# Altium schematic sheet SX1280_Power_Display_e394v02a.SchDoc(SX1280_Power_Display_e394v02a)
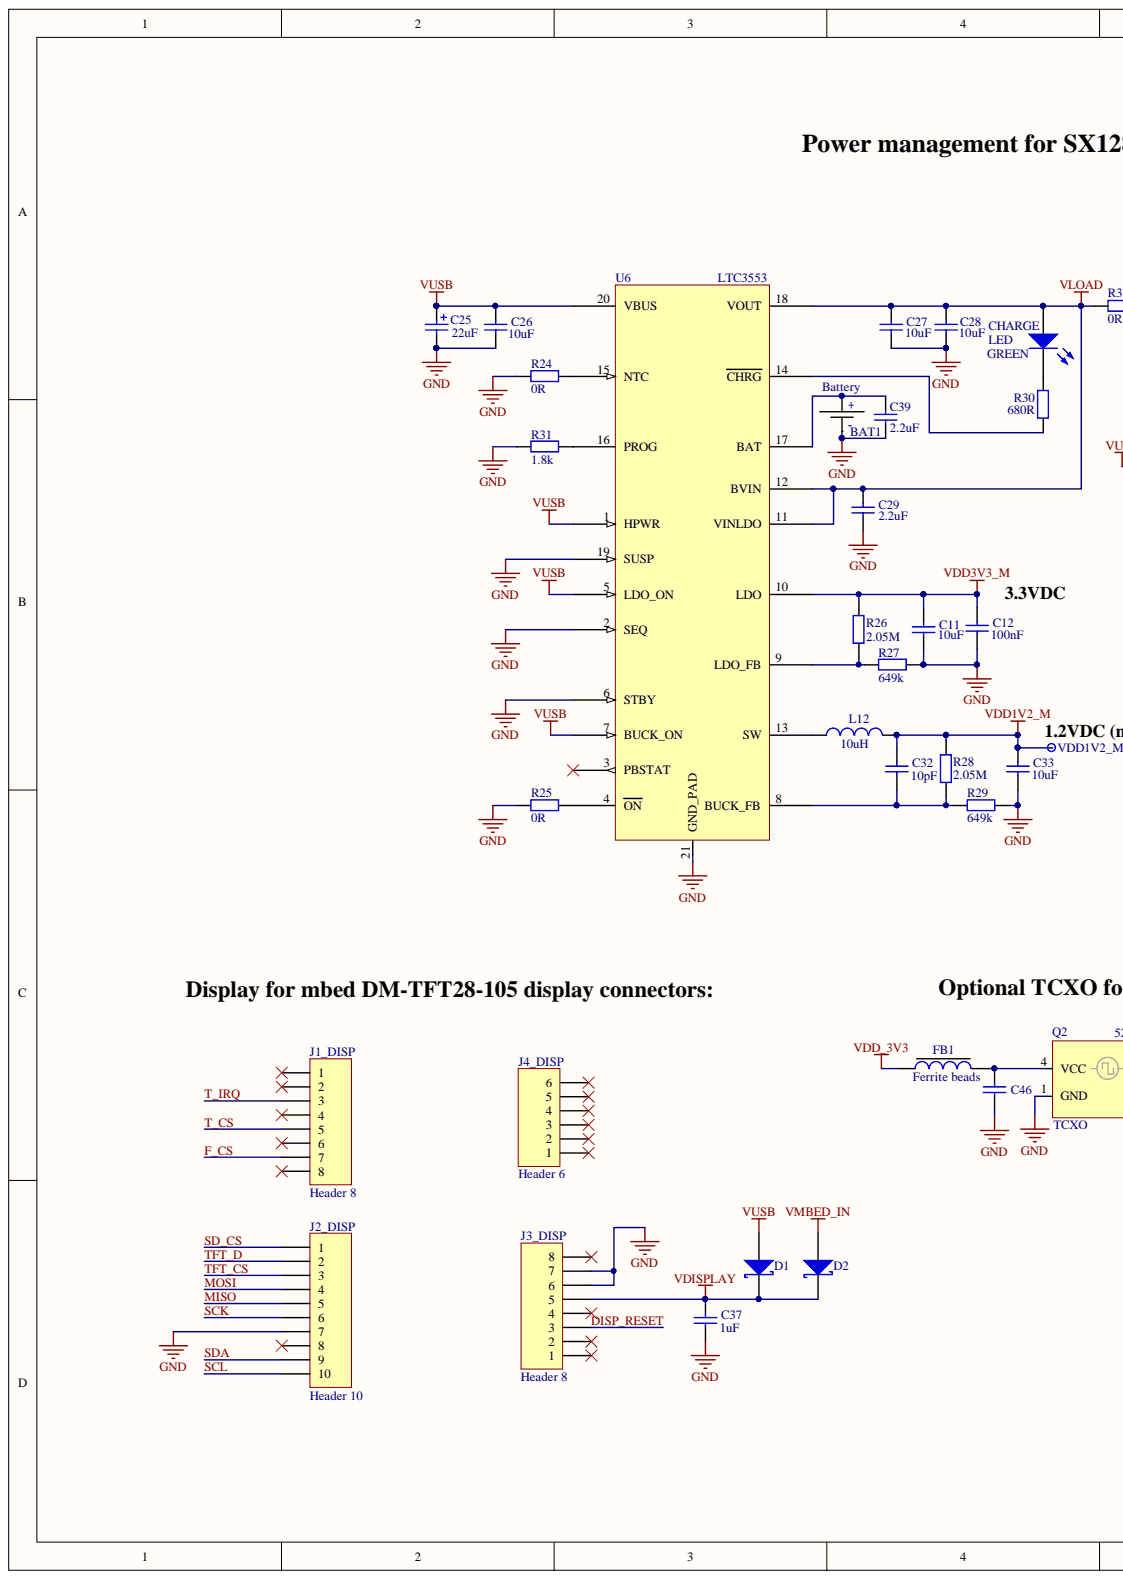
[diagram p0: SX1280_Power_Display_e394v02a.SchDoc, left]
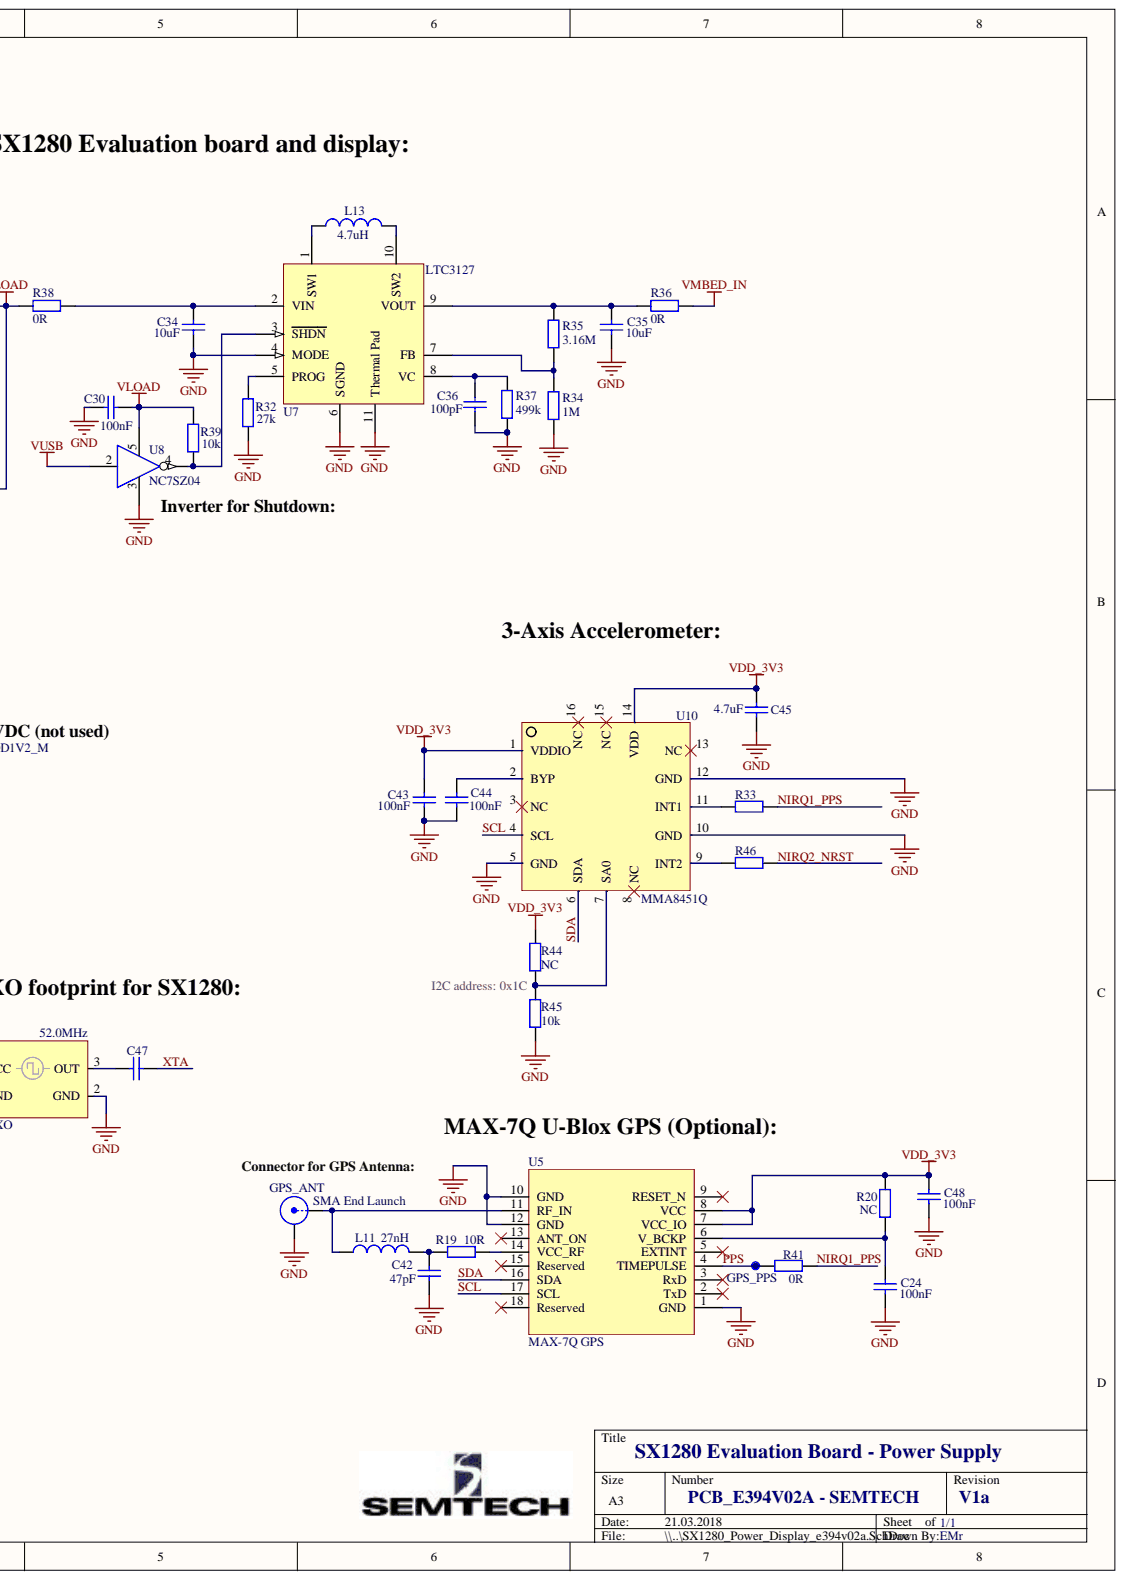
[diagram p1: SX1280_Power_Display_e394v02a.SchDoc, right]

## Parts
BAT1  Battery  [S2B-PH-K-S]
C11  value 10uF  [rlc_805_smd]  (hidden comment: Cap)
C12  value 100nF  [rlc_402_smd]  (hidden comment: Cap)
C24  value 100nF  [rlc_402_smd]  (hidden comment: Cap)
C25  value 22uF  [CD3216-1206]  (hidden comment: Cap Pol)
C26  value 10uF  [rlc_805_smd]  (hidden comment: Cap)
C27  value 10uF  [rlc_805_smd]  (hidden comment: Cap)
C28  value 10uF  [rlc_805_smd]  (hidden comment: Cap)
C29  value 2.2uF  [rlc_603_smd]  (hidden comment: Cap)
C30  value 100nF  [rlc_402_smd]  (hidden comment: Cap)
C32  value 10pF  [rlc_402_smd]  (hidden comment: Cap)
C33  value 10uF  [rlc_805_smd]  (hidden comment: Cap)
C34  value 10uF  [rlc_805_smd]  (hidden comment: Cap)
C35  value 10uF  [rlc_805_smd]  (hidden comment: Cap)
C36  value 100pF  [rlc_402_smd]  (hidden comment: Cap)
C37  value 1uF  [rlc_402_smd]  (hidden comment: Cap)
C39  value 2.2uF  [rlc_603_smd]  (hidden comment: Cap)
C42  value 47pF  [rlc_402_smd]  (hidden comment: Cap)
C43  value 100nF  [rlc_402_smd]  (hidden comment: Cap)
C44  value 100nF  [rlc_402_smd]  (hidden comment: Cap)
C45  value 4.7uF  [rlc_603_smd]  (hidden comment: Cap)
C46  Cap  [rlc_402_smd]  (value not drawn)
C47  Cap  [rlc_402_smd]  (value not drawn)
C48  value 100nF  [rlc_402_smd]  (hidden comment: Cap)
CHARGE  LED  value GREEN  [LGM676 LED]
D1  D Schottky single  [SOD123]  (value not drawn)
D2  D Schottky single  [SOD123]  (value not drawn)
FB1  Ferrite beads  [rlc_402_smd]
GPS_ANT  SMA End Launch  [SMA END LAUNCH JACK RECEPTACLE SHORT]
GPS_PPS  Test Pin  [pin_1mm]  (value not drawn)
J1_DISP  Header 8  [HDR1X8]
J2_DISP  Header 10  [HDR1X10]
J3_DISP  Header 8  [HDR1X8]
J4_DISP  Header 6  [HDR1X6]
L11  value 27nH  [rlc_402_smd]  (hidden comment: Inductor)
L12  value 10uH  [L4818]  (hidden comment: Inductor)
L13  value 4.7uH  [L4818]  (hidden comment: Inductor)
Q2  TCXO  value 52.0MHz  [TCXO 2.0x1.6mm]
R19  value 10R  [rlc_402_smd]  (hidden comment: Resistor)
R20  value NC  [rlc_402_smd]  (hidden comment: Resistor)
R24  value 0R  [rlc_402_smd]  (hidden comment: Resistor)
R25  value 0R  [rlc_402_smd]  (hidden comment: Resistor)
R26  value 2.05M  [rlc_402_smd]  (hidden comment: Resistor)
R27  value 649k  [rlc_402_smd]  (hidden comment: Resistor)
R28  value 2.05M  [rlc_402_smd]  (hidden comment: Resistor)
R29  value 649k  [rlc_402_smd]  (hidden comment: Resistor)
R30  value 680R  [rlc_402_smd]  (hidden comment: Resistor)
R31  value 1.8k  [rlc_402_smd]  (hidden comment: Resistor)
R32  value 27k  [rlc_402_smd]  (hidden comment: Resistor)
R33  Resistor  [rlc_402_smd]  (value not drawn)
R34  value 1M  [rlc_402_smd]  (hidden comment: Resistor)
R35  value 3.16M  [rlc_402_smd]  (hidden comment: Resistor)
R36  value 0R  [rlc_603_smd]  (hidden comment: Resistor)
R37  value 499k  [rlc_402_smd]  (hidden comment: Resistor)
R38  value 0R  [rlc_603_smd]  (hidden comment: Resistor)
R39  value 10k  [rlc_402_smd]  (hidden comment: Resistor)
R41  value 0R  [rlc_402_smd]  (hidden comment: Resistor)
R44  value NC  [rlc_402_smd]  (hidden comment: Resistor)
R45  value 10k  [rlc_402_smd]  (hidden comment: Resistor)
R46  Resistor  [rlc_402_smd]  (value not drawn)
U5  MAX-7Q GPS  [MAX-7]
U6  LTC3553  [vqfn20_3x3mm]
U7  LTC3127  [DD10_L]
U8  NC7SZ04  [SC70-5]
U10  MMA8451Q  [vqfn16_3x3mm]
VDD1V2_M  Test Pin  [pin_1mm]  (value not drawn)

## Nets
GND: BAT1.2 C11.1 C12.1 C24.2 C25.2 C26.1 C27.1 C28.1 C29.1 C30.1 C33.1 C34.1 C35.1 C36.1 C37.1 C39.1 C42.2 C43.1 C44.1 C45.1 C46.1 C48.2 GPS_ANT.2 J2_DISP.7 J3_DISP.6 J3_DISP.7 Q2.1(GND) Q2.2(GND) R24.2 R25.2 R27.2 R29.2 R31.2 R32.2 R34.1 R37.1 R45.2 U5.1(GND) U5.10(GND) U5.12(GND) U6.2(SEQ) U6.6(STBY) U6.19(SUSP) U6.21(GND_PAD) U7.4(MODE) U7.6(SGND) U7.11(Thermal_Pad) U8.3 U10.5 U10.10 U10.12
VDD1V2_M: C32.2 C33.2 L12.2 R28.1 VDD1V2_M.1
VDD3V3_M: C11.2 C12.2 R26.1 U6.10(LDO)
VDD_3V3: C43.2 C45.2 C48.1 FB1.1 R20.1 R44.1 U5.7(VCC_IO) U5.8(VCC) U10.1 U10.14
VDISPLAY: C37.2 D1.2 D2.2 J3_DISP.5
VLOAD: C27.2 C28.2 C29.2 C30.2 CHARGE.1 R38.1 R39.1 U6.11(VINLDO) U6.12(BVIN) U6.18(VOUT) U8.5
VMBED_IN: D2.1 R36.2
VUSB: C25.1 C26.2 D1.1 U6.1(HPWR) U6.5(LDO_ON) U6.7(BUCK_ON) U6.20(VBUS) U8.2
DISP_RESET: J3_DISP.3
F_CS: J1_DISP.7
MISO: J2_DISP.5
MOSI: J2_DISP.4
NIRQ1_PPS: R33.2 R41.2
NIRQ2_NRST: R46.2
PPS: GPS_PPS.1 R41.1 U5.4(TIMEPULSE)
SCK: J2_DISP.6
SCL: J2_DISP.10 U5.17(SCL) U10.4
SD_CS: J2_DISP.1
SDA: J2_DISP.9 U5.16(SDA) U10.6
T_CS: J1_DISP.5
T_IRQ: J1_DISP.3
TFT_CS: J2_DISP.3
TFT_D: J2_DISP.2
XTA: C47.2
NetBAT1_1: BAT1.1 C39.2 U6.17(BAT)
NetC24_1: C24.1 R20.2 U5.6(V_BCKP)
NetC32_1: C32.1 R28.2 R29.1 U6.8(BUCK_FB)
NetC34_2: C34.2 R38.2 U7.2(VIN)
NetC35_2: C35.2 R35.2 R36.1 U7.9(VOUT)
NetC36_2: C36.2 R37.2 U7.8(VC)
NetC42_1: C42.1 L11.2 R19.2
NetC44_2: C44.2 U10.2
NetC46_2: C46.2 FB1.2 Q2.4(VCC)
NetC47_1: C47.1 Q2.3(OUT)
NetCHARGE_2: CHARGE.2 R30.2
NetGPS_ANT_1: GPS_ANT.1 L11.1 U5.11(RF_IN)
NetL12_1: L12.1 U6.13(SW)
NetL13_1: L13.1 U7.1(SW1)
NetL13_2: L13.2 U7.10(SW2)
NetR19_1: R19.1 U5.14(VCC_RF)
NetR24_1: R24.1 U6.15(NTC)
NetR25_1: R25.1 U6.4(ON)
NetR26_2: R26.2 R27.1 U6.9(LDO_FB)
NetR30_1: R30.1 U6.14(CHRG)
NetR31_1: R31.1 U6.16(PROG)
NetR32_1: R32.1 U7.5(PROG)
NetR33_1: R33.1 U10.11
NetR34_2: R34.2 R35.1 U7.7(FB)
NetR39_2: R39.2 U7.3(SHDN) U8.4
NetR44_2: R44.2 R45.1 U10.7
NetR46_1: R46.1 U10.9

Not connected: J1_DISP 1 2 4 6 8; J2_DISP 8; J3_DISP 1 2 4 8; J4_DISP 1 2 3 4 5 6; U5 2(TxD) 3(RxD) 5(EXTINT) 9(RESET_N) 13(ANT_ON) 15(Reserved) 18(Reserved); U6 3(PBSTAT); U10 3 8 13 15 16

## Library data of the parts
BAT1 = Battery: Baterry standard modif; Library Name: SX1280_e394v02a.SchLib; Component Kind: Standard
C11 C12 C24 C26-C30 C32-C37 C39 C42-C44 C48 = Cap: Capacitor SMD; Signal Integrity: Cap Semi; Published: 8-Jun-2000; Library Name: SX1280_e394v02a.SchLib; LatestRevisionNote: Re-released for DXP Platform.; LatestRevisionDate: 05-oct-2007  -  Semtech; Component Kind: Standard; Publisher: Altium Limited
  C11 C12 C26-C30 C32-C37 C39 C43 C44: Simulation: CAP
C25 = Cap Pol: Polarized Capacitor (Surface Mount); Simulation: CAP; Signal Integrity: Cap Pol; Published: 8-Jun-2000; Library Name: SX1280_e394v02a.SchLib; LatestRevisionNote: Re-released for DXP Platform.; LatestRevisionDate: 05-oct-2007  -  Semtech; Component Kind: Standard; Publisher: Altium Limited
C45 = Cap: Capacitor SMD; Value voltage: 16VDC; Simulation: CAP; Signal Integrity: Cap Semi; Published: 8-Jun-2000; Library Name: SX1280_e394v02a.SchLib; LatestRevisionNote: Re-released for DXP Platform.; LatestRevisionDate: 05-oct-2007  -  Semtech; Component Kind: Standard; Publisher: Altium Limited
C46 = Cap: Capacitor SMD; Value: 100nF; Simulation: CAP; Signal Integrity: Cap Semi; Published: 8-Jun-2000; Library Name: SX1280_e394v02a.SchLib; LatestRevisionNote: Re-released for DXP Platform.; LatestRevisionDate: 05-oct-2007  -  Semtech; Component Kind: Standard; Publisher: Altium Limited
C47 = Cap: Capacitor SMD; Value: 15pF; Simulation: CAP; Signal Integrity: Cap Semi; Published: 8-Jun-2000; Library Name: SX1280_e394v02a.SchLib; LatestRevisionNote: Re-released for DXP Platform.; LatestRevisionDate: 05-oct-2007  -  Semtech; Component Kind: Standard; Publisher: Altium Limited
D1 D2 = D Schottky single: Schottky Diode; Library Name: SX1280_e394v02a.SchLib; Component Kind: Standard
FB1 = Ferrite beads: Value: NC; Library Name: SX1280_e394v02a.SchLib; Description: Ferrite beads; Component Kind: Standard
GPS_ANT = SMA: SMA Straight Connector; Library Name: SX1280_e394v02a.SchLib; LatestRevisionNote: Re-released for DXP Platform.; LatestRevisionDate: 05-oct-2007  -  Semtech; Component Kind: Standard; Publisher: Altium Limited
GPS_PPS VDD1V2_M = test_pin: Library Name: SX1280_e394v02a.SchLib; LatestRevisionDate: 05-oct-2007  -  Semtech; Description: Test Pin; Component Kind: Standard
J1_DISP J3_DISP = Header 8: Header, 8-Pin; Signal Integrity: Connector; Library Name: SX1280_e394v02a.SchLib; LatestRevisionNote: Re-released for DXP Platform.; LatestRevisionDate: 17-Jul-2002; Component Kind: Standard; Publisher: Altium Limited
J2_DISP = Header 10: Header, 10-Pin; Signal Integrity: Connector; Library Name: SX1280_e394v02a.SchLib; LatestRevisionNote: Re-released for DXP Platform.; LatestRevisionDate: 17-Jul-2002; Component Kind: Standard; Publisher: Altium Limited
J4_DISP = Header 6: Header, 6-Pin; Signal Integrity: Connector; Library Name: SX1280_e394v02a.SchLib; LatestRevisionNote: Re-released for DXP Platform.; LatestRevisionDate: 17-Jul-2002; Component Kind: Standard; Publisher: Altium Limited
L11 = Inductor: Inductor SMD; Code_IEC: 1005; Simulation: INDUCTOR; Signal Integrity: Inductor; Published: 8-Jun-2000; Library Name: SX1280_e394v02a.SchLib; LatestRevisionNote: Re-released for DXP Platform.; LatestRevisionDate: 05-oct-2007  -  Semtech; Component Kind: Standard; Code_JEITA: 0402; Publisher: Altium Limited
L12 L13 = Inductor: Code_IEC: 1005; Published: 8-Jun-2000; Library Name: SX1280_e394v02a.SchLib; LatestRevisionNote: Re-released for DXP Platform.; LatestRevisionDate: 05-oct-2007  -  Semtech; Description: Inductor; Component Kind: Standard; Code_JEITA: 0402; Publisher: Altium Limited
R19 R20 R24-R32 R34-R39 R41 R44 R45 = Resistor: Resistor SMD - IEC symbol version; Library Name: SX1280_e394v02a.SchLib; LatestRevisionDate: 27-Jul-20  -  Semtech; Component Kind: Standard
R33 R46 = Resistor: Resistor SMD - IEC symbol version; Value: NC; Library Name: SX1280_e394v02a.SchLib; LatestRevisionDate: 27-Jul-20  -  Semtech; Component Kind: Standard
U5 = MAX-7Q: MAX-7Q; U-Blox; 7 GPS/GNSS modules; Library Name: SX1280_e394v02a.SchLib; Component Kind: Standard
U6 = LTC3553: Micropower USB Power Manager With Li-Ion Charger,  LDO and Buck Regulator; Library Name: SX1280_e394v02a.SchLib; ComponentLink1URL: http://www.linear.com/; Component Kind: Standard
U7 = LTC3127: LTC3127EDD#PBF - 1A Buck-Boost DC/DC  Converter with Programmable  Input Current Limit; Published: 30-May-2008; PackageVersion: Jul-2005; PackageReference: DD10; PackageDescription: 10-Pin Plastic DFN, 3 x 3 x 0.8 mm Body, 0.5 mm Pitch, No Pullback, Exposed Pad (DD10); P/N: LTC3127EDD#PBF; Library Name: SX1280_e394v02a.SchLib; LatestRevisionNote: Thermal pad adjusted to match vendors recommendation, VIN type changed to power.; LatestRevisionDate: 4-Feb-2010; DatasheetVersion: Aug-2007; ComponentLink2URL: http://www.linear.com/pc/downloadDocument.do?navId=H0,C1,C1003,C1042,C1035,P7546,D5130; ComponentLink2Description: Datasheet; ComponentLink1URL: http://www.linear.com/; ComponentLink1Description: Manufacturer Link; Component Kind: Standard; Code_JEDEC: MO-229-WEED-2; Publisher: Altium Limited
U8 = NC7SZ04: NC7SZ04 - TinyLogic HS Single Inverter - Fairchild Semi; Library Name: SX1280_e394v02a.SchLib; Component Kind: Standard
U10 = MMA8451Q: Xtrinsic MMA8451Q 3-Axis, 14-bit/8-bit Digital Accelerometer; Library Name: SX1280_e394v02a.SchLib; Component Kind: Standard
CHARGE = LED: Library Name: SX1280_e394v02a.SchLib; LatestRevisionDate: 05-oct-2007  -  Semtech; Description: LED; Component Kind: Standard
Q2 = TCXO: Library Name: SX1280_e394v02a.SchLib; Description: TCXO; Component Kind: Standard
